# Altium schematic sheet main_power_switch.SchDoc(main_power_switch)
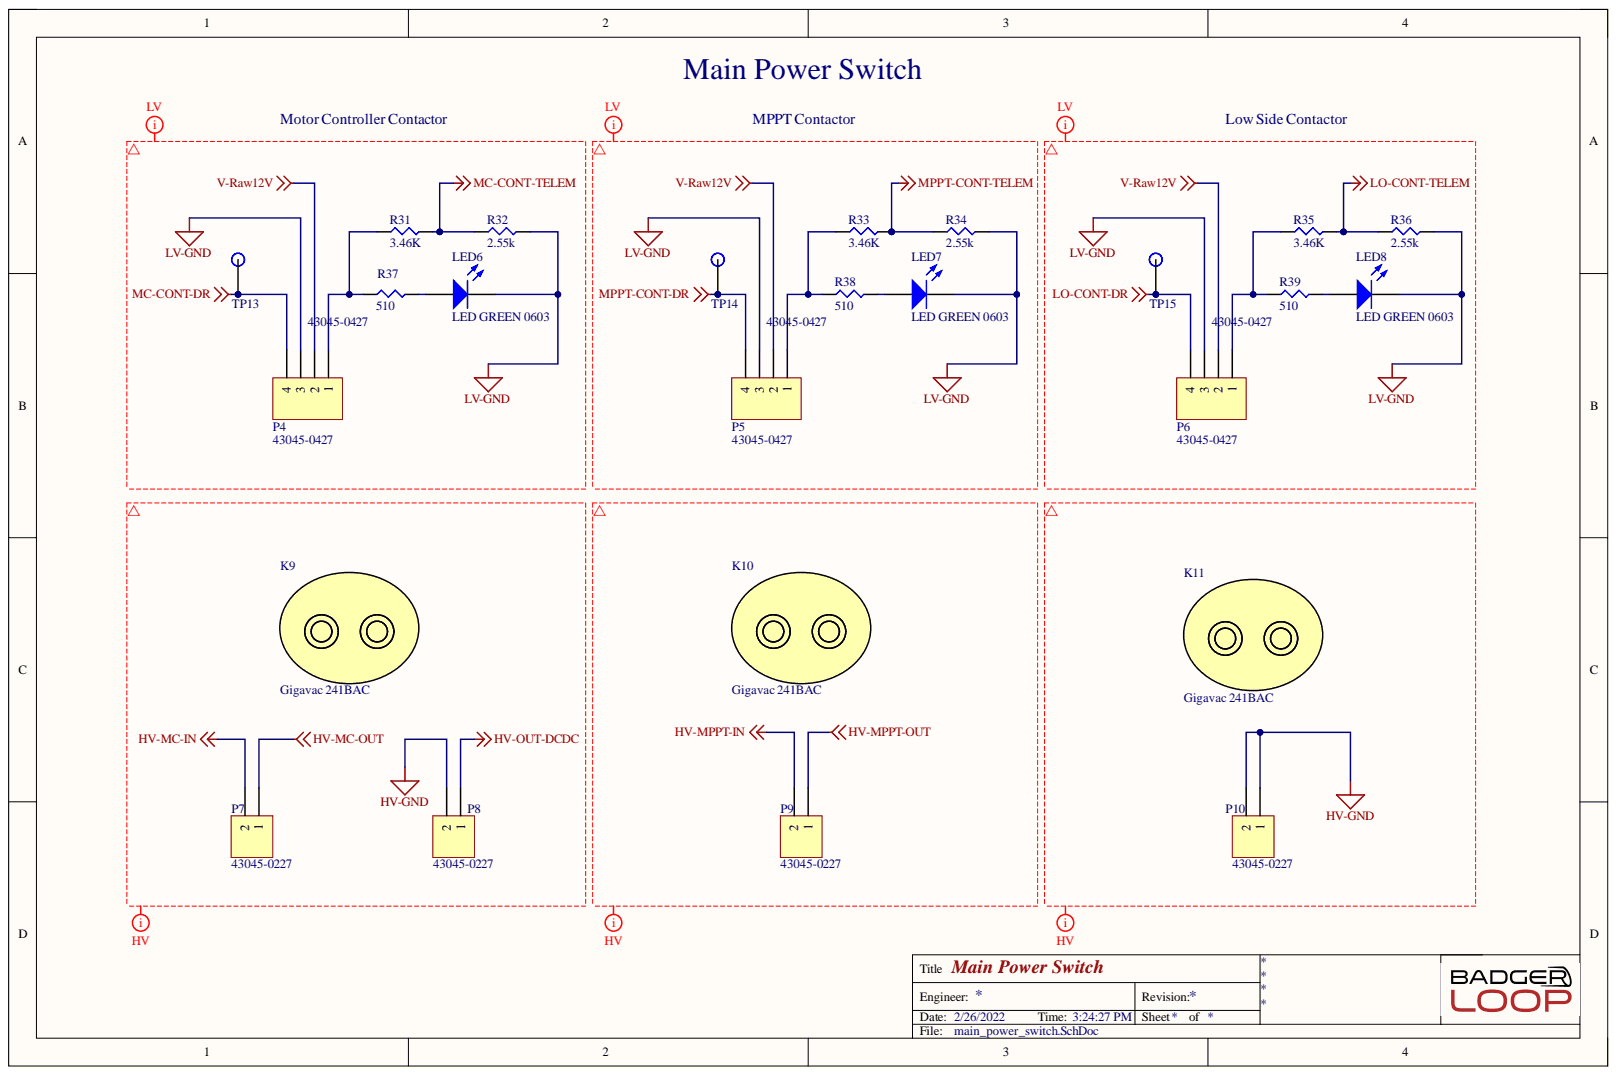
[diagram p0: main_power_switch.SchDoc]

## Parts
K9  Gigavac 241BAC  [Gigavac 200]
K10  Gigavac 241BAC  [Gigavac 200]
K11  Gigavac 241BAC  [Gigavac 200]
LED6  LED GREEN 0603  Color: GREEN  [LED 0603 GREEN]
LED7  LED GREEN 0603  Color: GREEN  [LED 0603 GREEN]
LED8  LED GREEN 0603  Color: GREEN  [LED 0603 GREEN]
P4  43045-0427  [430450427]
P5  43045-0427  [430450427]
P6  43045-0427  [430450427]
P7  43045-0227  [43045-0277]
P8  43045-0227  [43045-0277]
P9  43045-0227  [43045-0277]
P10  43045-0227  [43045-0277]
R31  3.46K  [SMD-0805-RES]
R32  2.55k  [SMD-1206-RES]
R33  3.46K  [SMD-0805-RES]
R34  2.55k  [SMD-1206-RES]
R35  3.46K  [SMD-0805-RES]
R36  2.55k  [SMD-1206-RES]
R37  RMCF1206FT510R  [SMD-1206-RES]  (value not drawn)
R38  RMCF1206FT510R  [SMD-1206-RES]  (value not drawn)
R39  RMCF1206FT510R  [SMD-1206-RES]  (value not drawn)
TP13  TP  [Test Point]
TP14  TP  [Test Point]
TP15  TP  [Test Point]

## Nets
HV-GND: P8.2 P10.1 P10.2
HV-MC-IN: P7.2
HV-MC-OUT: P7.1
HV-MPPT-IN: P9.2
HV-MPPT-OUT: P9.1
HV-OUT-DCDC: P8.1
LO-CONT-DR: P6.4 TP15.1
LO-CONT-TELEM: R35.2 R36.1
LV-GND: LED6.2 LED7.2 LED8.2 P4.3 P5.3 P6.3 R32.2 R34.2 R36.2
MC-CONT-DR: P4.4 TP13.1
MC-CONT-TELEM: R31.2 R32.1
MPPT-CONT-DR: P5.4 TP14.1
MPPT-CONT-TELEM: R33.2 R34.1
V-Raw12V: P4.2 P5.2 P6.2
NetLED6_1: LED6.1 R37.2
NetLED7_1: LED7.1 R38.2
NetLED8_1: LED8.1 R39.2
NetP4_1: P4.1 R31.1 R37.1
NetP5_1: P5.1 R33.1 R38.1
NetP6_1: P6.1 R35.1 R39.1

## Library data of the parts
LED6-LED8 = LED GREEN 0603: Manufacturer: Lite-On Inc.; Manufacturer P/N: LTST-C190KGKT; Component Kind: Standard; Digikey P/N: 160-1435-1-ND; Library Name: misc.SchLib; Package / Case: 0603
P4-P6 = 43045-0427: Board Connector, 4 Contact(s), 2 Row(s), Male, Straight, Solder Terminal, Locking, Black Insulator, Receptacle; Manufacturer: Molex; Board Mounting Option: PEG; Body Breadth: 0.29inch; Body Depth: 0.39inch; Body Length: 0.38inch; Contact Resistance: 10mR; Contact Style: SQ PIN-SKT; DIN Conformance: No; Insertion Force-Max: 8.0064N; Insulation Resistance: 1GR; Mating Information: MULTIPLE MATING PARTS AVAILABLE; Number Of Connectors: 1; Number of Contacts: 4; Number of Rows Loaded: 2; Option: General Purpose; Plating Thickness: 6uin; Rated Current (Signal): 2.5A; Reference Standard: UL; Reliability: COMMERCIAL; Throw Configuration: S0P4; Withdrawl Force-Min: 3.5584N; Body/Shell Style: RECEPTACLE; Category: Headers and Wire Housings; Component Kind: Standard; ComponentLink1Description: https://datasheet.ciiva.com:443/pdfs/VipMasterIC/IC/MOLE/MOLE-S-A0001360181/MOLE-S-A0001399497-1.pdf?src-supplier=IHS+Markit; ComponentLink1URL: https://datasheet.ciiva.com:443/pdfs/VipMasterIC/IC/MOLE/MOLE-S-A0001360181/MOLE-S-A0001399497-1.pdf?src-supplier=IHS+Markit; ComponentLink2Description: https://datasheet.ciiva.com/5148/0430450427-pcb-headers-142988-5148953.pdf?src-supplier=Mouser; ComponentLink2URL: https://datasheet.ciiva.com/5148/0430450427-pcb-headers-142988-5148953.pdf?src-supplier=Mouser; ComponentLink3Description: https://datasheet.ciiva.com/11748/0900766b80d4a80b-11748554.pdf?src-supplier=RSComponents; ComponentLink3URL: https://datasheet.ciiva.com/11748/0900766b80d4a80b-11748554.pdf?src-supplier=RSComponents; ComponentLink4Description: https://datasheet.ciiva.com/30143/176090430450427-pcb-headers-30143652.pdf?src-supplier=Onlinecomponents.com; ComponentLink4URL: https://datasheet.ciiva.com/30143/176090430450427-pcb-headers-30143652.pdf?src-supplier=Onlinecomponents.com; ComponentLink5Description: https://datasheet.ciiva.com/16725/0430450427-pcb-headers-16725499.pdf?src-supplier=Avnet+Europe; ComponentLink5URL: https://datasheet.ciiva.com/16725/0430450427-pcb-headers-16725499.pdf?src-supplier=Avnet+Europe; ComponentLink6Description: https://datasheet.ciiva.com/10088/0430450427-pcb-headers-10088767.pdf?src-supplier=Avnet; ComponentLink6URL: https://datasheet.ciiva.com/10088/0430450427-pcb-headers-10088767.pdf?src-supplier=Avnet; Connector Type: BOARD CONNECTOR; Contact Finish - Mating: TIN (100) OVER NICKEL; Contact Finish - Termination: TIN (100) OVER NICKEL; Contact Gender: Male; Contact Material: Brass; Filter Feature: No; Flammability Rating: UL94 V-0; IEC Conformance: No; Insulation Color: Black; Insulation Material: Polymer; JESD-609 Code: e3; Library Name: connector.SchLib; Manufacturer Part Number: 43045-0427; Max Operating Temperature: 105°C; MIL Conformance: No; Min Operating Temperature: -40°C; Mixed Contacts: No; Mount: Board; Mounting Option 1: LOCKING; Mounting Style: STRAIGHT; Pricing 1: 1=1.45000, 10=1.23200, 80=1.01750, 2232=0.77647, 4464=0.74970 (USD); REACH SVHC: Yes; RoHS: Yes; RoHS Compliant: Yes; Stock 1: 18088; Supplier 1: Digi-Key; Supplier Part Number 1: WM10667-ND; Terminal Length: 0.125inch; Terminal Pitch: 3mm; Termination: Solder
P7-P10 = 43045-0227: Board Connector, 2 Contact(s), 2 Row(s), Male, Straight, Solder Terminal, Locking, Black Insulator, Receptacle; Manufacturer: Molex; Board Mounting Option: PEG; Body Breadth: 0.29inch; Body Depth: 0.39inch; Body Length: 0.262inch; Contact Resistance: 10mR; Contact Style: SQ PIN-SKT; DIN Conformance: No; Insertion Force-Max: 8.0064N; Insulation Resistance: 1GR; Mating Information: MULTIPLE MATING PARTS AVAILABLE; Number Of Connectors: 1; Number of Contacts: 2; Option: General Purpose; Plating Thickness: 6uin; Rated Current (Signal): 2.5A; Reference Standard: UL; Reliability: COMMERCIAL; Throw Configuration: S0P2; Withdrawl Force-Min: 3.5584N; Body/Shell Style: RECEPTACLE; Category: Headers and Wire Housings; Component Kind: Standard; ComponentLink1Description: https://datasheet.ciiva.com:443/pdfs/VipMasterIC/IC/MOLE/MOLE-S-A0001360181/MOLE-S-A0001399497-1.pdf?src-supplier=IHS+Markit; ComponentLink1URL: https://datasheet.ciiva.com:443/pdfs/VipMasterIC/IC/MOLE/MOLE-S-A0001360181/MOLE-S-A0001399497-1.pdf?src-supplier=IHS+Markit; ComponentLink2Description: https://datasheet.ciiva.com/5148/0430450227-pcb-headers-142611-5148694.pdf?src-supplier=Mouser; ComponentLink2URL: https://datasheet.ciiva.com/5148/0430450227-pcb-headers-142611-5148694.pdf?src-supplier=Mouser; ComponentLink3Description: https://datasheet.ciiva.com/16103/307170430450227-pcb-headers-16103122.pdf?src-supplier=Onlinecomponents.com; ComponentLink3URL: https://datasheet.ciiva.com/16103/307170430450227-pcb-headers-16103122.pdf?src-supplier=Onlinecomponents.com; ComponentLink4Description: https://datasheet.ciiva.com/11752/0900766b80d4a80b-11752890.pdf?src-supplier=RSComponents; ComponentLink4URL: https://datasheet.ciiva.com/11752/0900766b80d4a80b-11752890.pdf?src-supplier=RSComponents; ComponentLink5Description: https://datasheet.ciiva.com/30256/212010430450227-pcb-headers-30256881.pdf?src-supplier=Onlinecomponents.com; ComponentLink5URL: https://datasheet.ciiva.com/30256/212010430450227-pcb-headers-30256881.pdf?src-supplier=Onlinecomponents.com; ComponentLink6Description: https://datasheet.ciiva.com/16725/0430450227-pcb-headers-16725483.pdf?src-supplier=Avnet+Europe; ComponentLink6URL: https://datasheet.ciiva.com/16725/0430450227-pcb-headers-16725483.pdf?src-supplier=Avnet+Europe; ComponentLink7Description: https://datasheet.ciiva.com/10089/0430450227-pcb-headers-10089453.pdf?src-supplier=Avnet; ComponentLink7URL: https://datasheet.ciiva.com/10089/0430450227-pcb-headers-10089453.pdf?src-supplier=Avnet; Connector Type: BOARD CONNECTOR; Contact Finish - Mating: TIN (100) OVER NICKEL; Contact Finish - Termination: TIN (100) OVER NICKEL; Contact Gender: Male; Contact Material: Brass; Filter Feature: No; Flammability Rating: UL94 V-0; IEC Conformance: No; Insulation Color: Black; Insulation Material: Polymer; JESD-609 Code: e3; Library Name: connector.SchLib; Manufacturer Part Number: 43045-0227; Max Operating Temperature: 105°C; MIL Conformance: No; Min Operating Temperature: -40°C; Mixed Contacts: No; Mount: Board; Mounting Option 1: LOCKING; Mounting Style: STRAIGHT; Number of Rows Loaded: 2; Pricing 1: 1=0.97000, 10=0.80600, 80=0.67200, 945=0.53760, 2400=0.48720 (USD); REACH SVHC: Yes; RoHS: Yes; RoHS Compliant: Yes; Stock 1: 12970; Supplier 1: Digi-Key; Supplier Part Number 1: WM10657-ND; Terminal Length: 0.125inch; Terminal Pitch: 3mm; Termination: Solder
R31 R33 R35 = CRCW08053K46FKTA: Fixed Resistor, Metal Glaze/thick Film, 0.125W, 3460ohm, 150V, 1% +/-Tol, 100ppm/Cel, Surface Mount, 0805; Manufacturer: Vishay Dale; Category: Chip SMD Resistors; Component Kind: Standard; ComponentLink1Description: https://datasheet.ciiva.com:443/pdfs/VipMasterIC/IC/VISH/VISHS12541/VISHS12541-1.pdf?src-supplier=IHS+Markit; ComponentLink1URL: https://datasheet.ciiva.com:443/pdfs/VipMasterIC/IC/VISH/VISHS12541/VISHS12541-1.pdf?src-supplier=IHS+Markit; ComponentLink2Description: http://www.vishay.com/docs/20008/dcrcw.pdf?src-supplier=Digi-Key; ComponentLink2URL: http://www.vishay.com/docs/20008/dcrcw.pdf?src-supplier=Digi-Key; ComponentLink3Description: http://www.vishay.com/doc?20008?src-supplier=Mouser; ComponentLink3URL: http://www.vishay.com/doc?20008?src-supplier=Mouser; Library Name: resistors.SchLib; Manufacturer Part Number: CRCW08053K46FKTA; Number of Pins: 2; Pricing 1: 1=0.17000, 10=0.13500, 50=0.13500, 100=0.05200, 1000=0.02300, 10000=0.01900 (USD); REACH SVHC: No SVHC; Resistor Type: FIXED RESISTOR; RoHS: No; RoHS Compliant: No; Stock 1: 3820; Supplier 1: Mouser; Supplier Part Number 1: 71-CRCW0805-3.46K
R32 R34 R36 = ERJ-8ENF2551V: RES SMD 2.55K OHM 1% 1/4W 1206; Manufacturer: Panasonic Electronic Components; @ qty: 1500; Factory Stock: 0; Minimum Quantity: 1; Power (Watts): 0.25W, 1/4W; Temperature Coefficient: ±100ppm/°C; Unit Price (USD): 0.00933; Component Kind: Standard; Composition: Thick Film; Datasheets: http://industrial.panasonic.com/www-cgi/jvcr13pz.cgi?E+PZ+3+AOA0002+ERJ8ENF2551V+7+WW; Digi-Key Part Number: P2.55KFCT-ND; Failure Rate: -; Features: Automotive AEC-Q200; Height - Seated (Max): 0.028" (0.70mm); Image: //media.digikey.com/Renders/Panasonic%20Renders/ERJ-1206%20Pkg.jpg; Library Name: resistors.SchLib; Number of Terminations: 2; Operating Temperature: -55°C ~ 155°C; Package / Case: 1206 (3216 Metric); Packaging: Cut Tape (CT); Part Number: ERJ-8ENF2551V; Part Status: Active; Quantity Available: 30143; Series: ERJ; Size / Dimension: 0.126" L x 0.063" W (3.20mm x 1.60mm); Supplier Device Package: 1206; Tolerance: ±1%; Value: 2.55k
R37-R39 = RMCF1206FT510R: Fixed Resistor, Metal Glaze/thick Film, 0.25W, 510ohm, 200V, 1% +/-Tol, 100ppm/Cel, Surface Mount, 1206; Manufacturer: Stackpole Electronics; Package Height: 0.55mm; Package Length: 3.2mm; Package Width: 1.6mm; Rated Power Dissipation (P): 250mW; Rated Temperature: 70°C; Reference Standard: AEC-Q200; Resistance: 510; Temperature Coefficient: 100ppm/°C; Category: Chip SMD Resistors; Component Kind: Standard; ComponentLink1Description: https://datasheet.ciiva.com:443/pdfs/VipMasterIC/IC/SEIE/SEIE-S-A0009541969/SEIE-S-A0009541969-1.pdf?src-supplier=IHS+Markit; ComponentLink1URL: https://datasheet.ciiva.com:443/pdfs/VipMasterIC/IC/SEIE/SEIE-S-A0009541969/SEIE-S-A0009541969-1.pdf?src-supplier=IHS+Markit; ComponentLink2Description: http://components.arrow.com/part/dataview/40948710S9551678N1174?src-supplier=Arrow+Electronics; ComponentLink2URL: http://components.arrow.com/part/dataview/40948710S9551678N1174?src-supplier=Arrow+Electronics; ComponentLink3Description: https://www.seielect.com/Catalog/SEI-RMCF_RMCP.pdf?src-supplier=Digi-Key; ComponentLink3URL: https://www.seielect.com/Catalog/SEI-RMCF_RMCP.pdf?src-supplier=Digi-Key; ComponentLink4Description: https://www.seielect.com/catalog/SEI-Packaging.pdf?src-supplier=Digi-Key; ComponentLink4URL: https://www.seielect.com/catalog/SEI-Packaging.pdf?src-supplier=Digi-Key; ComponentLink5Description: https://www.seielect.com/catalog/SEI-RMCF_RMCP.pdf?src-supplier=Avnet; ComponentLink5URL: https://www.seielect.com/catalog/SEI-RMCF_RMCP.pdf?src-supplier=Avnet; Construction: Rectangular; JESD-609 Code: e3; Library Name: resistors.SchLib; Manufacturer Part Number: RMCF1206FT510R; Max Operating Temperature: 155°C; Min Operating Temperature: -55°C; Mount: Surface Mount; Number of Pins: 2; Package Shape: Rectangular; Package Style: SMTMeter; Packaging: Tape and Reel; Pricing 1: 1=0.10000, 10=0.03600, 100=0.01460, 1000=0.00655, 2500=0.00569 (USD); REACH SVHC: Yes; Resistor Type: FIXED RESISTOR; RoHS: Yes; RoHS Compliant: Yes; Size Code: 1206; Stock 1: 51; Supplier 1: Digi-Key; Supplier Part Number 1: RMCF1206FT510RCT-ND; Technology: METAL GLAZE/THICK FILM; Terminal Finish: Nickel; Termination: Wraparound; Tolerance: 1%; Working Voltage: 200V
TP13-TP15 = TP: SMD TEST POINT; Component Kind: Standard; Library Name: misc.SchLib
K9-K11 = Gigavac 241BAC: Component Kind: Standard; Library Name: misc.SchLib
